# Altium schematic sheet CONNECT.SchDoc(CONNECT)
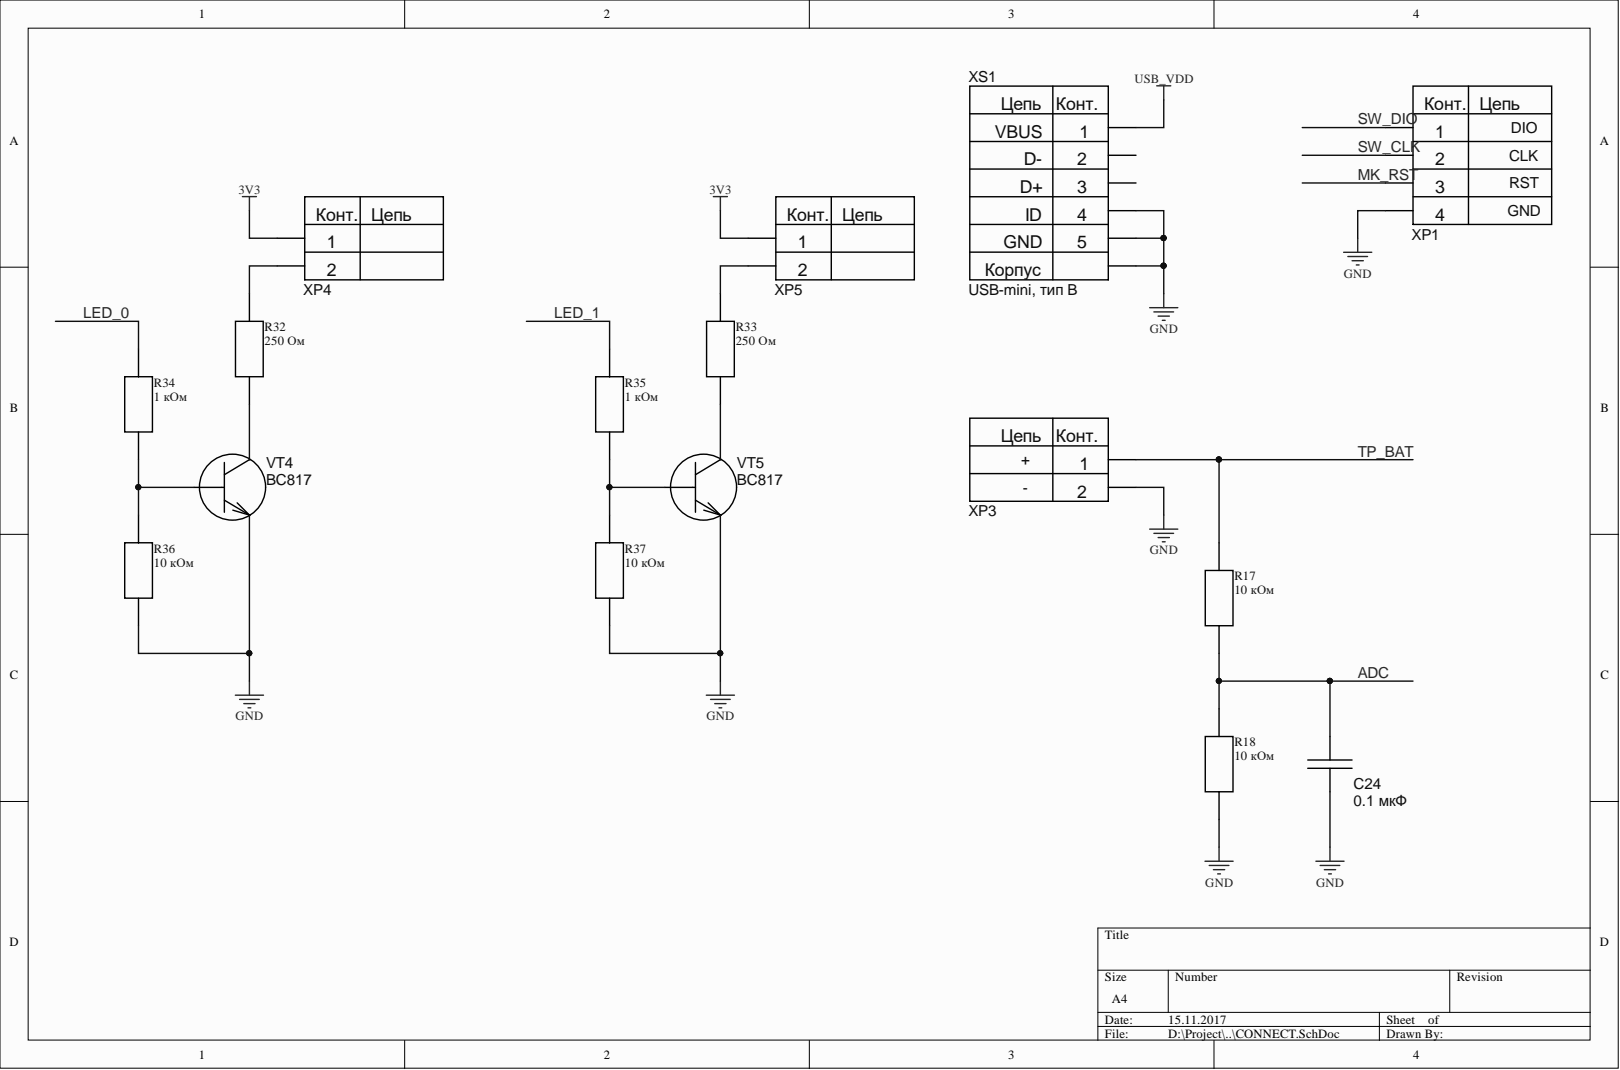
[diagram p0: CONNECT.SchDoc]

## Parts
C24  0.1 мкФ  [C 1206]
R17  10 кОм  [RES 0805]
R18  10 кОм  [RES 0805]
R32  250 Ом  [RES 0805]
R33  250 Ом  [RES 0805]
R34  1 кОм  [RES 0805]
R35  1 кОм  [RES 0805]
R36  10 кОм  [RES 0805]
R37  10 кОм  [RES 0805]
VT4  BC817  [SOT-23]
VT5  BC817  [SOT-23]
XP1  PLD-4  [PLD-4]  (value not drawn)
XP3  PLS-2  [PLS-2]  (value not drawn)
XP4  PLS-2  [PLS-2]  (value not drawn)
XP5  PLS-2  [PLS-2]  (value not drawn)
XS1  USB-mini, тип B  [USB_mini_B]

## Nets
3V3: XP4.1 XP5.1
GND: C24.2 R18.1 R36.2 R37.2 VT4.2 VT5.2 XP1.4 XP3.2 XS1.0 XS1.4 XS1.5
USB_VDD: XS1.1
ADC: C24.1 R17.1 R18.2
LED_0: R34.1
LED_1: R35.1
MK_RST: XP1.3
SW_CLK: XP1.2
SW_DIO: XP1.1
TP_BAT: R17.2 XP3.1
NetR32_1: R32.1 XP4.2
NetR32_2: R32.2 VT4.3
NetR33_1: R33.1 XP5.2
NetR33_2: R33.2 VT5.3
NetR34_2: R34.2 R36.1 VT4.1
NetR35_2: R35.2 R37.1 VT5.1

Not connected: XS1 2 3

## Library data of the parts
C24 = CAP 1206: SMD Capacitor 1206; Manufacturer: /Укажите компанию-производителя/; Type: Конденсатор керамический; Tolerance: /Укажите допуск в процентах/; Temperature coefficient: /Формат: ±? ppm/°C/; TC: /Укажите тип диэлектрика/; Value: 0.1 мкФ; Temperature range: /Формат: -? ... ? °C/; Size: 1206; Library Name: my_lib.SchLib; Component Kind: Standard
R17 R18 R32-R37 = RES 0805: Library Name: my_lib.SchLib; Component Kind: Standard
VT4 VT5 = BC817: NPN general-purpose transistor; Manufacturer: NXP; Type: Транзистор биполярный; Structure: n-p-n; Specitication: ЭКБ ИП; Power dissitipation: 0,25 Вт; Max emitter-base voltage: 5 В; Max collector peak current: 1 А; Max collector current: 0,5 А; Max collector-emitter voltage: 45 В; Max collector-base voltage: 50 В; hFE: 160 ... 600; fT: 100 МГц; Complement: BC807; Collector-emitter saturation voltage: 0,7 В; Collector-base сapacitance: 3 пФ; Base-emitter on voltage: 1,2 В; Temperature range: -65 ... 150 °C; Library Name: my_lib.SchLib; Component Kind: Standard
XP1 = PLD-4: PLD connector; Manufacturer: NONAME; Number of contacts: 4; Connector type: Вилка на плату; Type: Разъем штыревой; Library Name: my_lib.SchLib; Component Kind: Standard
XP3-XP5 = PLS-2: PLS connector; Manufacturer: NONAME; Number of contacts: 2; Connector type: Вилка на плату; Type: Разъем штыревой; Library Name: my_lib.SchLib; Component Kind: Standard
XS1 = USB-mini_B: USB-mini-B connector; Manufacturer: NONAME; Number of contacts: 5; Connector type: USB-mini, тип B на плату; Type: Разъем; Library Name: my_lib.SchLib; Component Kind: Standard
